# Altium schematic sheet CanTransceiver.SchDoc
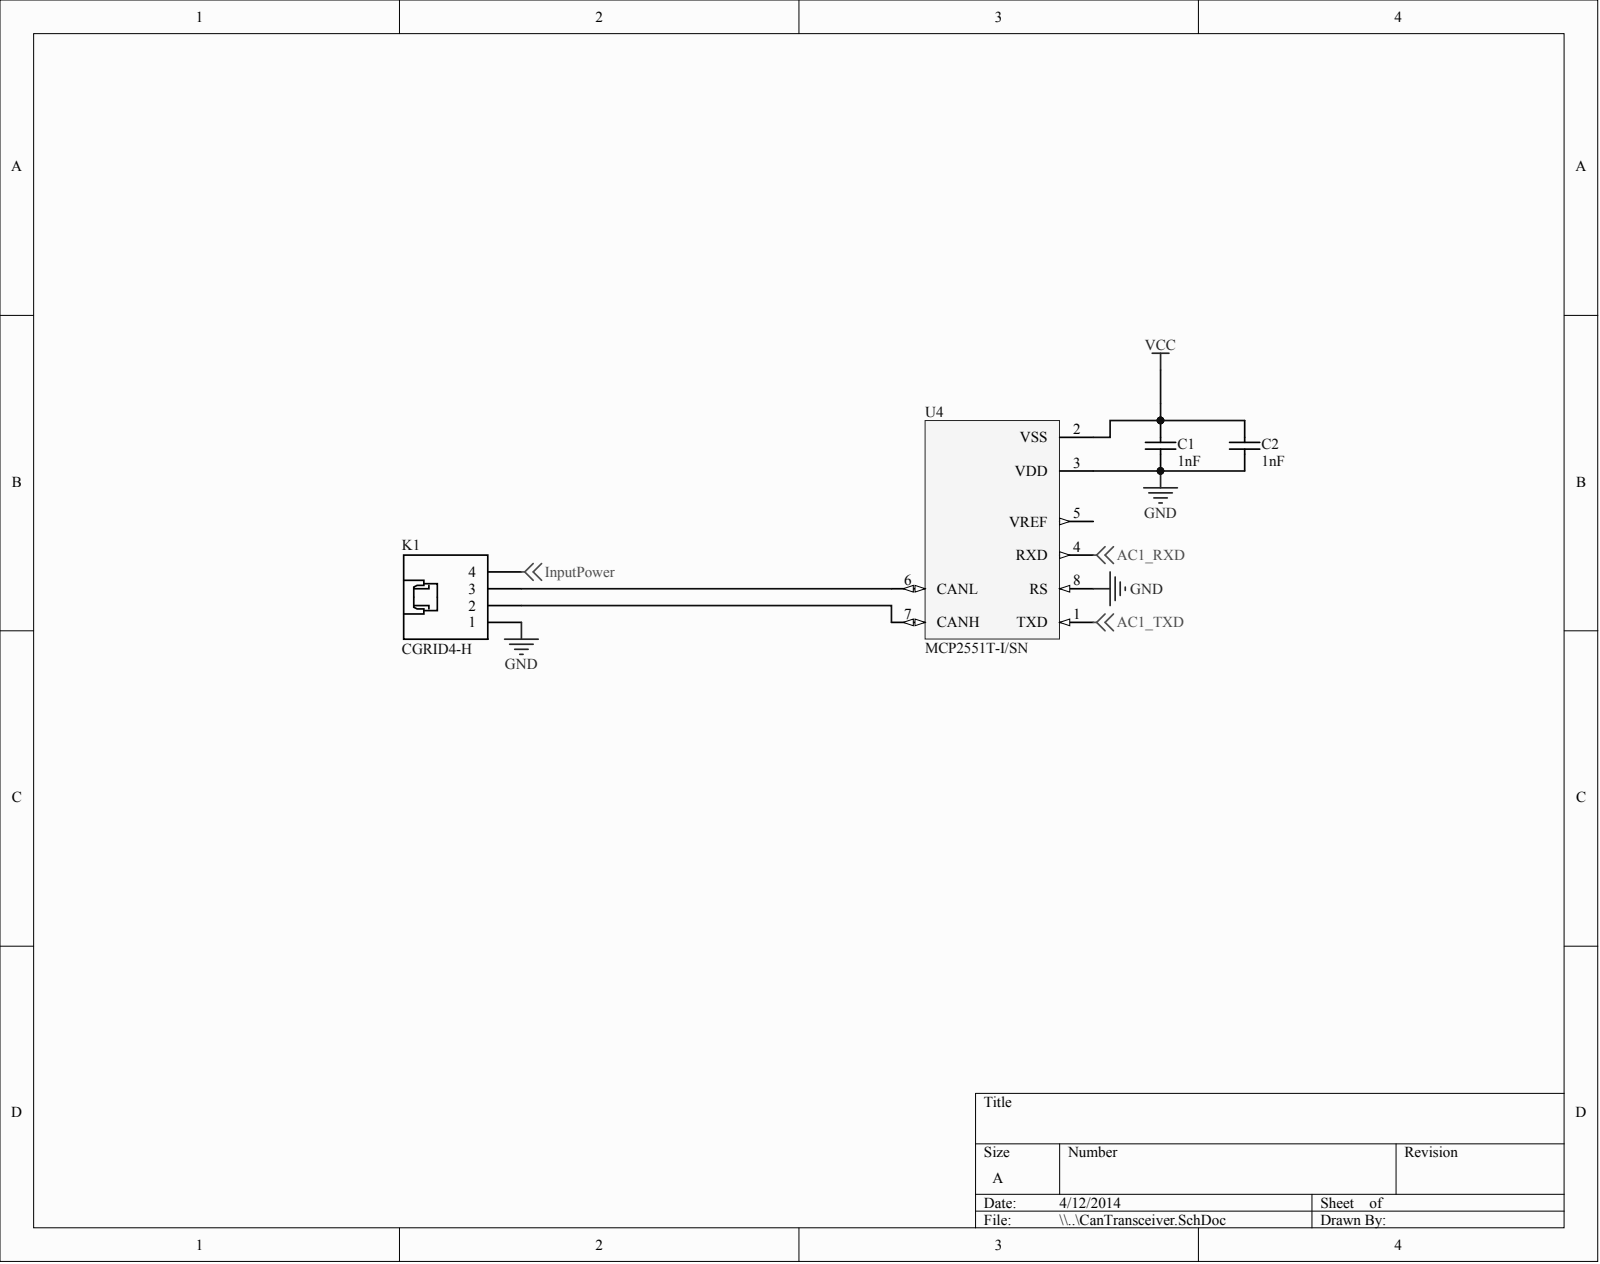
[diagram p0: CanTransceiver.SchDoc]

## Parts
C1  1nF
C2  1nF
K1  CGRID4-H
U4  MCP2551T-I/SN

## Nets
AC1_RXD: U4.4(RXD)
AC1_TXD: U4.1(TXD)
GND: C1.2 C2.2 K1.1 U4.3(VDD) U4.8(RS)
INPUTPOWER: K1.4
VCC: C1.1 C2.1 U4.2(VSS)
NetK1_2: K1.2 U4.7(CANH)
NetK1_3: K1.3 U4.6(CANL)

Not connected: U4 5(VREF)

## Library data of the parts
C1 C2 K1 U4 = (no symbol name)
  K1: Supplier 1: Digi-Key; Supplier Part Number 1: WM4902-ND
  U4: Published: 29-Jan-2009; DatasheetVersion: revE, Jan-2007; PackageVersion: revBB, Aug-2009; PackageReference: SOIC-SN8; PackageDescription: 8-Lead Plastic Small Outline (SN) - Narrow, 3.90mm Body [SOIC]; Supplier 1: Digi-Key; Supplier Part Number 1: MCP2551T-I/SNTR-ND; Supplier 2: Farnell; Supplier Part Number 2: 1788569; Code_JEDEC: MS-012; Code_IPC: SOIC127P600-8; ComponentLink1Description: Datasheet; ComponentLink1URL: http://ww1.microchip.com/downloads/en/DeviceDoc/21667E.pdf; ComponentLink2Description: Packaging Specification; ComponentLink2URL: http://www.microchip.com/stellent/groups/techpub_sg/documents/packagingspec/en012702.pdf; ComponentLink3Description: Microchip.com Home; ComponentLink3URL: http://www.microchip.com/; Publisher: Altium Limited
